# Altium schematic sheet Capacitive.SchDoc
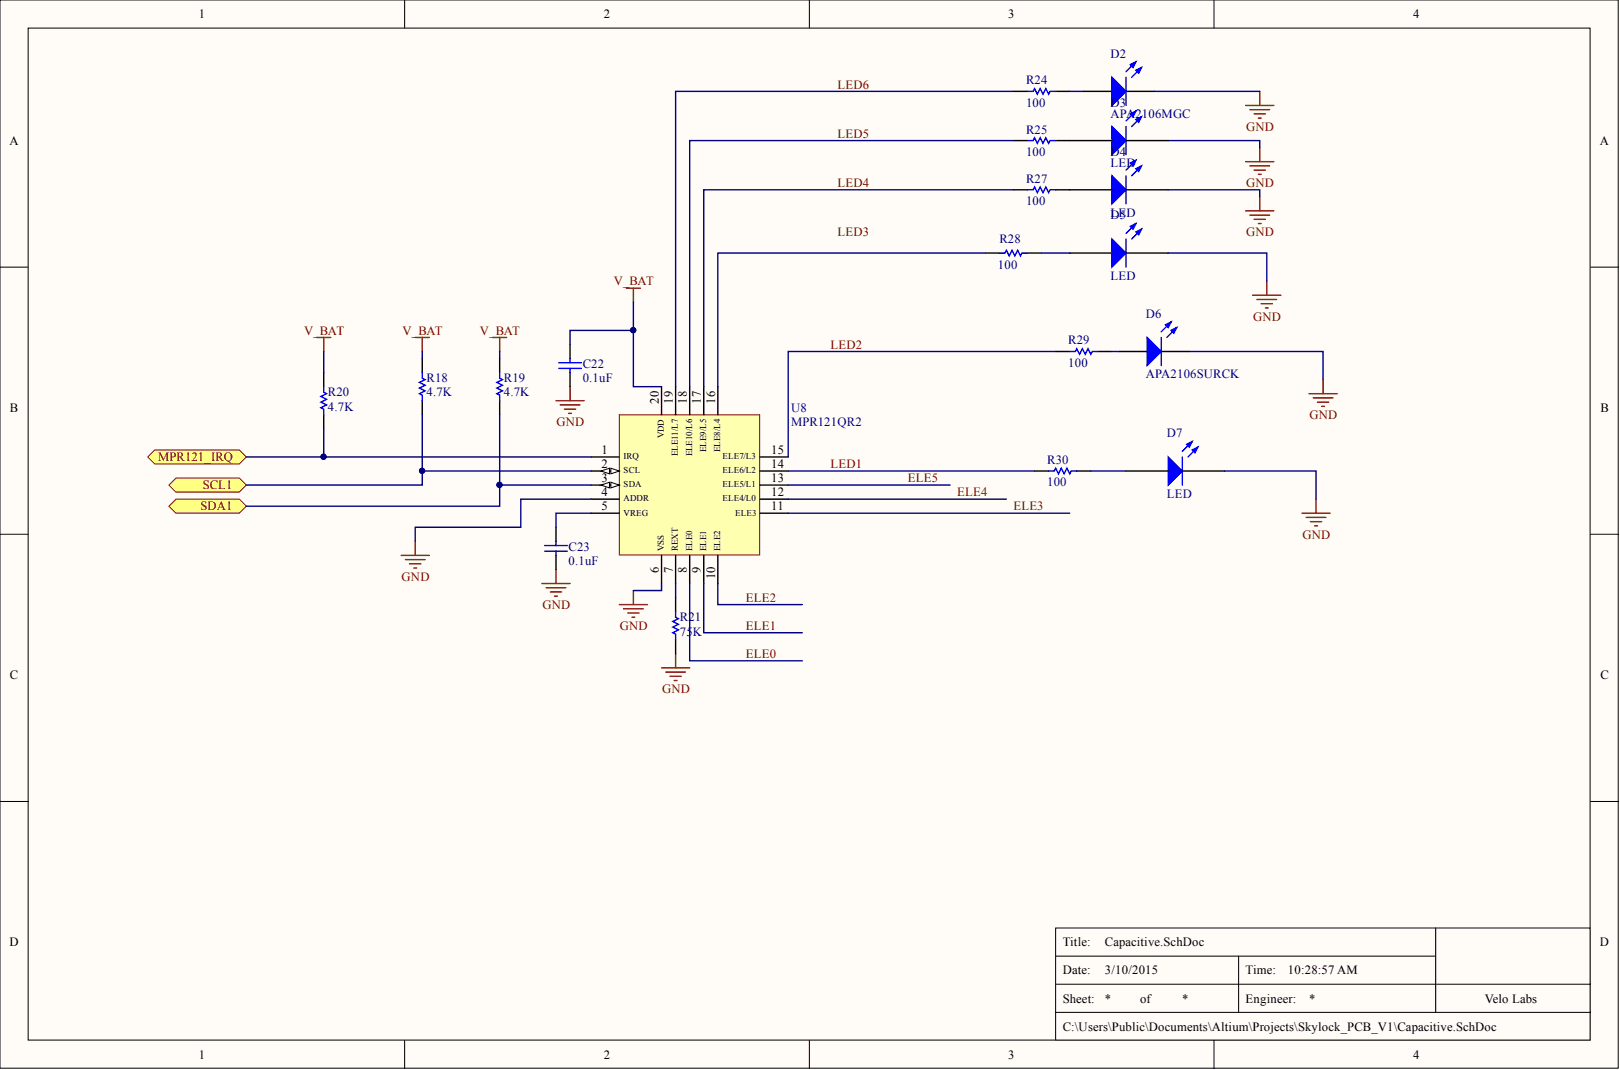
[diagram p0: Capacitive.SchDoc]

## Parts
C22  0.1uF  [C0402]
C23  0.1uF  [C0402]
D2  APA2106MGC  [L0805RA]
D3  LED  [L0805RA]
D4  LED  [L0805RA]
D5  LED  [L0805RA]
D6  APA2106SURCK  [L0805RA]
D7  LED  [L0805RA]
R18  4.7K  [R0402]
R19  4.7K  [R0402]
R20  4.7K  [R0402]
R21  75K  [R0402]
R24  100  [R0402]
R25  100  [R0402]
R27  100  [R0402]
R28  100  [R0402]
R29  100  [R0402]
R30  100  [R0402]
U8  MPR121QR2  [QFN40P300X300X60-20L]

## Ports
MPR121_IRQ, SCL1, SDA1

## Nets
GND: C22.2 C23.2 D2.2 D3.2 D4.2 D5.2 D6.2 D7.2 R21.2 U8.4(ADDR) U8.6(VSS)
V_BAT: C22.1 R18.1 R19.1 R20.1 U8.20(VDD)
ELE0: U8.8(ELE0)
ELE1: U8.9(ELE1)
ELE2: U8.10(ELE2)
ELE3: U8.11(ELE3)
ELE4: U8.12(ELE4/L0)
ELE5: U8.13(ELE5/L1)
LED1: R30.1 U8.14(ELE6/L2)
LED2: R29.1 U8.15(ELE7/L3)
LED4: R27.1 U8.17(ELE9/L5)
LED5: R25.1 U8.18(ELE10/L6)
LED6: R24.1 U8.19(ELE11/L7)
MPR121_IRQ: R20.2 U8.1(IRQ) ; port MPR121_IRQ
SCL1: R18.2 U8.2(SCL) ; port SCL1
SDA1: R19.2 U8.3(SDA) ; port SDA1
NetC23_1: C23.1 U8.5(VREG)
NetD2_1: D2.1 R24.2
NetD3_1: D3.1 R25.2
NetD4_1: D4.1 R27.2
NetD5_1: D5.1 R28.2
NetD6_1: D6.1 R29.2
NetD7_1: D7.1 R30.2
NetR21_1: R21.1 U8.7(REXT)
NetR28_1: R28.1 U8.16(ELE8/L4)
LED3:

## Library data of the parts
C22 C23 = Cap Semi: Capacitor; Manufacturer: Murata Electronics North America; Manufacturer Part Number: GRM155R61A104KA01D; Capacitance: 0.1µF; Temperature Coefficient: X5R; Tolerance: ±10%; Voltage - Rated: 10V; Applications: General Purpose; Category: Capacitors; Component Kind: Standard; ComponentLink1Description: http://www.murata.com/~/media/webrenewal/support/library/catalog/products/capacitor/mlcc/c02e.ashx; ComponentLink1URL: http://www.murata.com/~/media/webrenewal/support/library/catalog/products/capacitor/mlcc/c02e.ashx; Features: -; Height - Seated (Max): -; LatestRevisionDate: 29-May-2009; LatestRevisionNote: IPC-7351 Footprint Added.; Lead Spacing: -; Lead Style: -; Mounting Type: Surface Mount, MLCC; Operating Temperature: -55°C ~ 85°C; Package / Case: 0402 (1005 Metric); PackageDescription: Chip Capacitor; PackageReference: C1206; Packaging: Cut Tape (CT); Pricing 1: 1=0.1, 10=0.012, 50=0.0066, 100=0.0055, 250=0.00452, 500=0.00386, 1000=0.003, 5000=0.0025 (USD); Published: 8-Jun-2000; Ratings: -; RoHS: RoHS Compliant; Signal Integrity: Cap Semi; Simulation: CAP; Size / Dimension: 0.039" L x 0.020" W (1.00mm x 0.50mm); Stock 1: 7992964; Supplier 1: Digi-Key; Supplier Part Number 1: 490-1318-1-ND; Thickness (Max): 0.022" (0.55mm); Value: 0.1uF; Library Name: VL_Skylock_Nordic.SCHLIB; Publisher: Altium Limited
D2 = APA2106MGC: Standard LEDs - SMD Green 570nm Water Clear 80mcd; Manufacturer: Kingbright; Forward Current: 20 mA; Forward Voltage: 2.1 V; LED Size: 2.1 mm x 0.6 mm x 1 mm; Viewing Angle: 120 deg; Category: Standard LEDs - SMD; Component Kind: Standard; ComponentLink1Description: http://www.kingbrightusa.com/images/catalog/SPEC/APA2106MGC.pdf; ComponentLink1URL: http://www.kingbrightusa.com/images/catalog/SPEC/APA2106MGC.pdf; Description 1: Standard LEDs - SMD Green 570nm Water Clear 80mcd; Illumination Color: Green; Luminous Intensity: 80 mcd; Manufacturer Part Number: APA2106MGC; Maximum Operating Temperature: + 85 C; Minimum Operating Temperature: - 40 C; Minimum Order Quantity: 1; Package / Case: 2.1 mm x 0.6 mm x 1 mm; Packaging: Reel; Pricing 1: 1=0.675, 10=0.423, 100=0.346, 1000=0.251, 2000=0.211, 10000=0.203, 24000=0.193, 50000=0.192 (AUD); Product Category: LED SMD Standard; Reeling: True; RoHS: RoHS Compliant; RoHS - Mouser: Y; Standard Pack Qty: 2000; Stock 1: 1095; Supplier 1: Mouser; Supplier Part Number 1: 604-APA2106MGC; Wavelength/Color Temperature: 570 nm; Library Name: VL_Skylock_Nordic.SCHLIB
D3-D5 D7 = APA2106SYCK: Standard LEDs - SMD Yellow 590nm Water Clear 150mcd; Brand: Kingbright; Forward Current: 20 mA; Forward Voltage: 2 V; LED Size: 2.1 mm x 0.6 mm x 1 mm; Viewing Angle: 120 deg; Category: Standard LEDs - SMD; Component Kind: Standard; ComponentLink1Description: http://www.mouser.com/ds/2/216/APA2106SYCK-20559.pdf; ComponentLink1URL: http://www.mouser.com/ds/2/216/APA2106SYCK-20559.pdf; Illumination Color: Yellow; Lens Color/Style: Water Clear; Luminous Intensity: 150 mcd; Manufacturer: Kingbright; Manufacturer Part Number: APA2106SYCK; Maximum Operating Temperature: + 85 C; Minimum Operating Temperature: - 40 C; Minimum Order Quantity: 1; Package / Case: 2.1 mm x 0.6 mm x 1 mm; Packaging: Reel; Pricing 1: 1=0.356, 10=0.218, 100=0.162, 1000=0.132, 2000=0.119, 10000=0.108, 24000=0.098, 50000=0.092, 100000=0.088 (AUD); Product Category: LED SMD Standard; Reeling: True; RoHS: RoHS Compliant; RoHS - Mouser: Y; Standard Pack Qty: 2000; Stock 1: 1805; Supplier 1: Mouser; Supplier Part Number 1: 604-APA2106SYCK; Wavelength/Color Temperature: 590 nm; Library Name: VL_Skylock_Nordic.SCHLIB
D6 = APA2106SURCK: Standard LEDs - SMD Hyper Red R.A. 635nm, 250mcd; Manufacturer: Kingbright; Forward Current: 20 mA; Forward Voltage: 1.95 V; LED Size: 2.1 mm x 0.6 mm x 1 mm; Viewing Angle: 120 deg; Wavelength/Color Temperature: 630 nm; Category: Standard LEDs - SMD; Component Kind: Standard; ComponentLink1Description: http://www.kingbrightusa.com/images/catalog/SPEC/APA2106SURCK.pdf; ComponentLink1URL: http://www.kingbrightusa.com/images/catalog/SPEC/APA2106SURCK.pdf; Description 1: Standard LEDs - SMD Hyper Red R.A. 635nm, 250mcd; Illumination Color: Red; Luminous Intensity: 250 mcd; Manufacturer Part Number: APA2106SURCK; Maximum Operating Temperature: + 85 C; Minimum Operating Temperature: - 40 C; Minimum Order Quantity: 1; Package / Case: 2.1 mm x 0.6 mm x 1 mm; Packaging: Reel; Pricing 1: 1=0.324, 10=0.198, 100=0.147, 1000=0.120, 2000=0.108, 10000=0.099, 24000=0.089, 50000=0.084, 100000=0.080 (AUD); Product Category: LED SMD Standard; Reeling: True; RoHS: RoHS Compliant; RoHS - Mouser: Y; Standard Pack Qty: 2000; Stock 1: 0; Supplier 1: Mouser; Supplier Part Number 1: 604-APA2106SURCK; Library Name: VL_Skylock_Nordic.SCHLIB
R18-R20 = Res: Resistor; Manufacturer: Panasonic Electronic Components; Manufacturer Part Number: ERJ-2GEJ472X; Power (Watts): 0.1W, 1/10W; Temperature Coefficient: ±200ppm/°C; Tolerance: ±5%; Category: Resistors; Component Kind: Standard; ComponentLink1Description: http://industrial.panasonic.com/www-cgi/jvcr13pz.cgi?E+PZ+3+AOA0001+ERJ2GEJ472X+7+WW; ComponentLink1URL: http://industrial.panasonic.com/www-cgi/jvcr13pz.cgi?E+PZ+3+AOA0001+ERJ2GEJ472X+7+WW; Composition: Thick Film; Features: Automotive AEC-Q200; Height: 0.016" (0.40mm); LatestRevisionDate: 17-Jul-2002; LatestRevisionNote: Re-released for DXP Platform.; Number of Terminations: 2; Operating Temperature: -55°C ~ 155°C; Package / Case: 0402 (1005 Metric); PackageDescription: Axial Device, Thru-Hole; 2 Leads; 0.3 in Pin Spacing; PackageReference: AXIAL-0.3; Packaging: Cut Tape (CT); Pricing 1: 1=0.1, 50=0.0138, 100=0.0105, 250=0.0078, 500=0.00576, 1000=0.00384, 5000=0.0027 (USD); Published: 8-Jun-2000; Resistance (Ohms): 4.7k; RoHS: RoHS Compliant; Signal Integrity: Res; Simulation: RESISTOR; Size / Dimension: 0.039" L x 0.020" W (1.00mm x 0.50mm); Stock 1: 3698322; Supplier 1: Digi-Key; Supplier Device Package: 0402; Supplier Part Number 1: P4.7KJCT-ND; Value: 4.7K; Library Name: VL_Skylock_Nordic.SCHLIB; Publisher: Altium Limited
R21 = Res: Resistor; Manufacturer: Panasonic Electronic Components; Manufacturer Part Number: ERJ-2RKF7502X; Power (Watts): 0.1W, 1/10W; Temperature Coefficient: ±100ppm/°C; Tolerance: ±1%; Category: Resistors; Component Kind: Standard; ComponentLink1Description: http://industrial.panasonic.com/www-cgi/jvcr13pz.cgi?E+PZ+3+AOA0002+ERJ2RKF7502X+7+WW; ComponentLink1URL: http://industrial.panasonic.com/www-cgi/jvcr13pz.cgi?E+PZ+3+AOA0002+ERJ2RKF7502X+7+WW; Composition: Thick Film; Features: Automotive AEC-Q200; Height: 0.016" (0.40mm); LatestRevisionDate: 17-Jul-2002; LatestRevisionNote: Re-released for DXP Platform.; Number of Terminations: 2; Operating Temperature: -55°C ~ 155°C; Package / Case: 0402 (1005 Metric); PackageDescription: Axial Device, Thru-Hole; 2 Leads; 0.3 in Pin Spacing; PackageReference: AXIAL-0.3; Packaging: Cut Tape (CT); Pricing 1: 1=0.1, 50=0.0196, 100=0.0149, 250=0.01104, 500=0.00816, 1000=0.00544, 5000=0.00383 (USD); Published: 8-Jun-2000; Resistance (Ohms): 75k; RoHS: RoHS Compliant; Signal Integrity: Res; Simulation: RESISTOR; Size / Dimension: 0.039" L x 0.020" W (1.00mm x 0.50mm); Stock 1: 158031; Supplier 1: Digi-Key; Supplier Device Package: 0402; Supplier Part Number 1: P75.0KLCT-ND; Value: 75K; Library Name: VL_Skylock_Nordic.SCHLIB; Publisher: Altium Limited
R24 R25 R27-R30 = Res: Resistor; Manufacturer: Panasonic Electronic Components; Manufacturer Part Number: ERJ-2GEJ101X; Power (Watts): 0.1W, 1/10W; Temperature Coefficient: ±200ppm/°C; Tolerance: ±5%; Category: Resistors; Component Kind: Standard; ComponentLink1Description: http://industrial.panasonic.com/www-cgi/jvcr13pz.cgi?E+PZ+3+AOA0001+ERJ2GEJ101X+7+WW; ComponentLink1URL: http://industrial.panasonic.com/www-cgi/jvcr13pz.cgi?E+PZ+3+AOA0001+ERJ2GEJ101X+7+WW; Composition: Thick Film; Features: Automotive AEC-Q200; Height: 0.016" (0.40mm); LatestRevisionDate: 17-Jul-2002; LatestRevisionNote: Re-released for DXP Platform.; Number of Terminations: 2; Operating Temperature: -55°C ~ 155°C; Package / Case: 0402 (1005 Metric); PackageDescription: Axial Device, Thru-Hole; 2 Leads; 0.3 in Pin Spacing; PackageReference: AXIAL-0.3; Packaging: Cut Tape (CT); Pricing 1: 1=0.1, 50=0.0138, 100=0.0105, 250=0.0078, 500=0.00576, 1000=0.00384, 5000=0.0027 (USD); Published: 8-Jun-2000; Resistance (Ohms): 100; RoHS: RoHS Compliant; Signal Integrity: Res; Simulation: RESISTOR; Size / Dimension: 0.039" L x 0.020" W (1.00mm x 0.50mm); Stock 1: 1362844; Supplier 1: Digi-Key; Supplier Device Package: 0402; Supplier Part Number 1: P100JCT-ND; Value: 100; Library Name: VL_Skylock_Nordic.SCHLIB; Publisher: Altium Limited
U8 = MPR121QR2: IC CTLR TOUCH SENSOR 20-QFN; Manufacturer: Freescale Semiconductor; Current - Supply: 29µA; Data Interface: I²C, Serial; Evaluation Tools: Available; Number of Inputs/Keys: 12 Key; Voltage - Supply: 1.71 V ~ 3.6 V; Category: Sensors, Transducers; Component Kind: Standard; ComponentLink1Description: http://www.freescale.com/files/sensors/doc/data_sheet/MPR121.pdf; ComponentLink1URL: http://www.freescale.com/files/sensors/doc/data_sheet/MPR121.pdf; Data Rate/Sampling Rate (SPS, BPS): -; Description 1: IC CTLR TOUCH SENSOR 20-QFN; Manufacturer Part Number: MPR121QR2; Mounting Type: Surface Mount; Operating Temperature: -40°C ~ 85°C; Package / Case: 20-UFQFN; Packaging: Cut Tape (CT); Pricing 1: 1=1.2, 10=1.075, 25=1.0104, 100=0.9675, 250=0.92452, 500=0.86 (USD); Resolution (Bits): -; RoHS: RoHS Compliant; Stock 1: 9356; Supplier 1: Digi-Key; Supplier Device Package: 20-QFN (3x3); Supplier Part Number 1: MPR121QR2CT-ND; Touch Panel Interface: -; Type: Capacitive, Proximity Sensor; Voltage Reference: -; Library Name: VL_Skylock_Nordic.SCHLIB
